# Altium schematic sheet PowerSupply.SchDoc
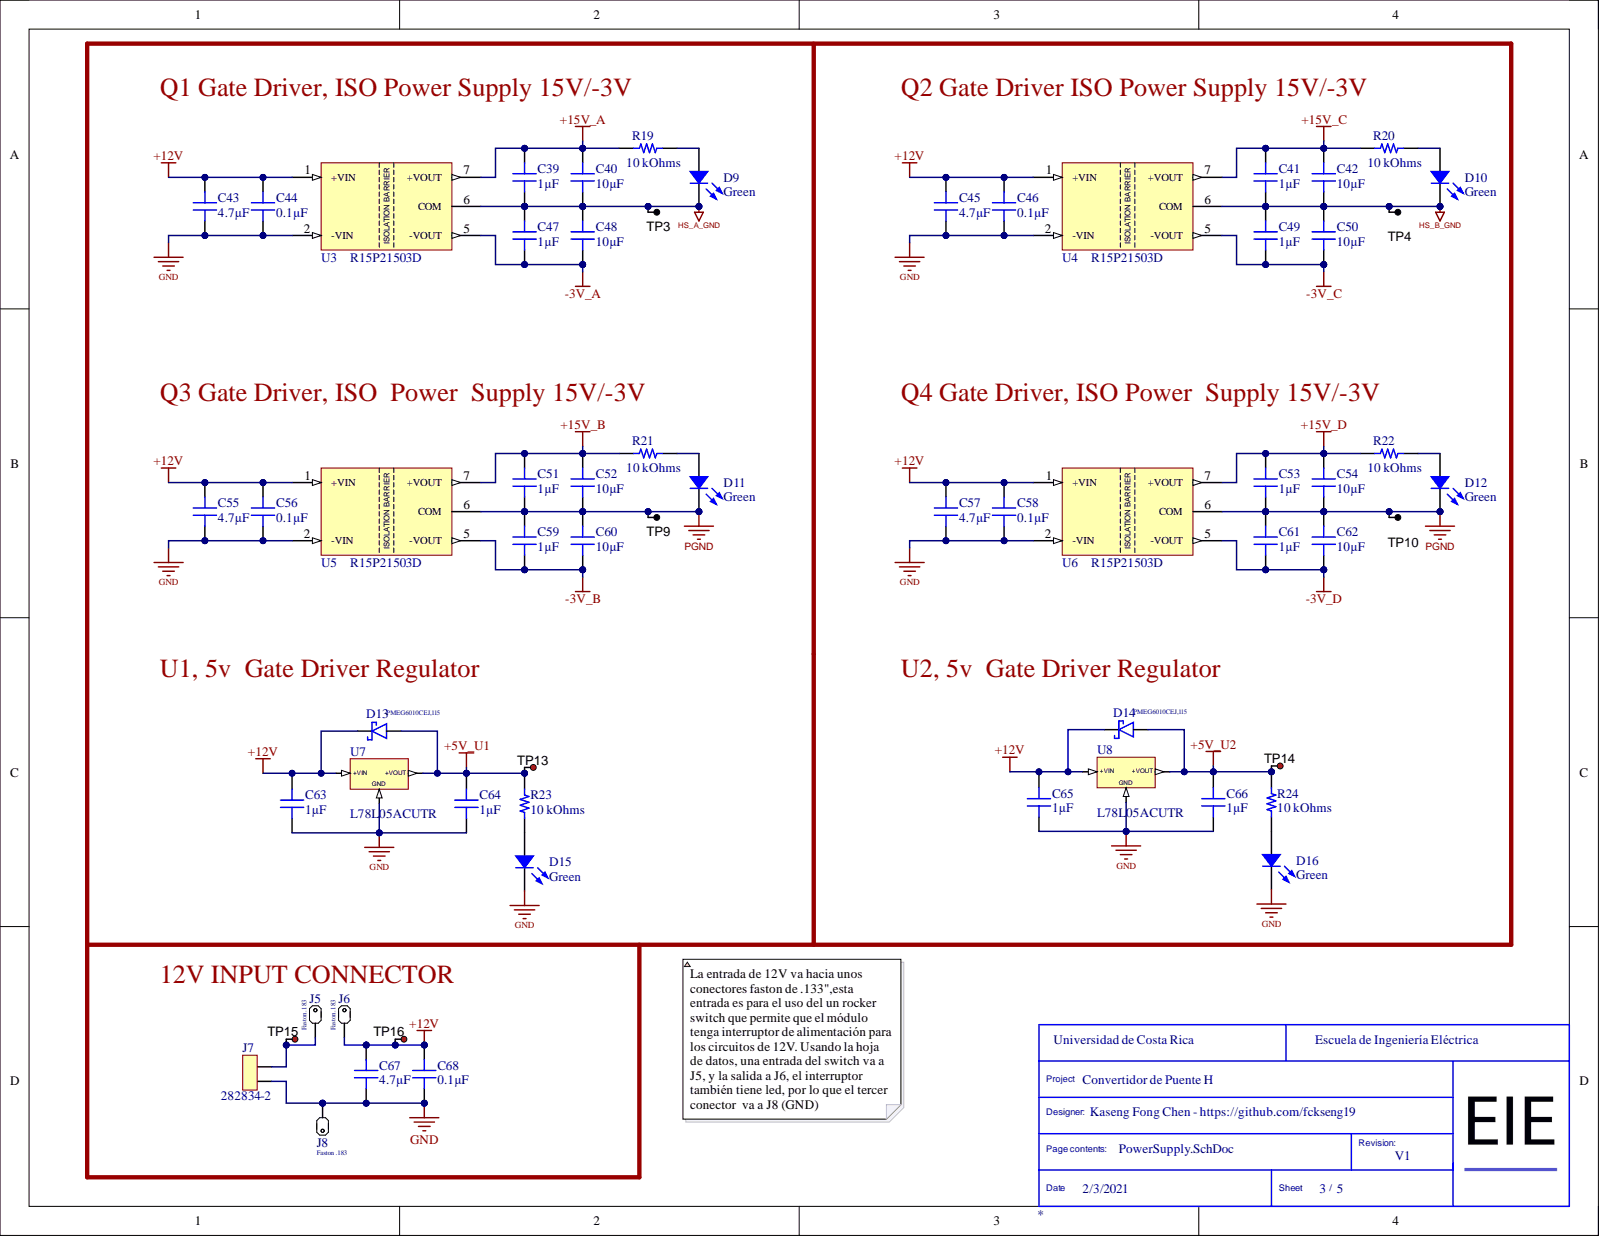
[diagram p0: PowerSupply.SchDoc]

## Parts
C39  1µF  [CAP 0603_1608]
C40  10µF  [CAP 1206_3216 - 1.8MM]
C41  1µF  [CAP 0603_1608]
C42  10µF  [CAP 1206_3216 - 1.8MM]
C43  4.7µF  [CAP 1206_3216 - 1.8MM]
C44  0.1µF  [CAP 0603_1608]
C45  4.7µF  [CAP 1206_3216 - 1.8MM]
C46  0.1µF  [CAP 0603_1608]
C47  1µF  [CAP 0603_1608]
C48  10µF  [CAP 1206_3216 - 1.8MM]
C49  1µF  [CAP 0603_1608]
C50  10µF  [CAP 1206_3216 - 1.8MM]
C51  1µF  [CAP 0603_1608]
C52  10µF  [CAP 1206_3216 - 1.8MM]
C53  1µF  [CAP 0603_1608]
C54  10µF  [CAP 1206_3216 - 1.8MM]
C55  4.7µF  [CAP 1206_3216 - 1.8MM]
C56  0.1µF  [CAP 0603_1608]
C57  4.7µF  [CAP 1206_3216 - 1.8MM]
C58  0.1µF  [CAP 0603_1608]
C59  1µF  [CAP 0603_1608]
C60  10µF  [CAP 1206_3216 - 1.8MM]
C61  1µF  [CAP 0603_1608]
C62  10µF  [CAP 1206_3216 - 1.8MM]
C63  1µF  [CAP 0603_1608]
C64  1µF  [CAP 0603_1608]
C65  1µF  [CAP 0603_1608]
C66  1µF  [CAP 0603_1608]
C67  4.7µF  [CAP 1206_3216 - 1.8MM]
C68  0.1µF  [CAP 0603_1608]
D9  Green  [LED 0603_1608 GREEN]
D10  Green  [LED 0603_1608 GREEN]
D11  Green  [LED 0603_1608 GREEN]
D12  Green  [LED 0603_1608 GREEN]
D13  PMEG6010CEJ,115  [SOD-323F]
D14  PMEG6010CEJ,115  [SOD-323F]
D15  Green  [LED 0603_1608 GREEN]
D16  Green  [LED 0603_1608 GREEN]
J5  Faston .183  [TE_1217133-1]
J6  Faston .183  [TE_1217133-1]
J7  282834-2  [TE 282834-2]
J8  Faston .183  [TE_1217133-1]
R19  10 kOhms  [RES 0603_1608]
R20  10 kOhms  [RES 0603_1608]
R21  10 kOhms  [RES 0603_1608]
R22  10 kOhms  [RES 0603_1608]
R23  10 kOhms  [RES 0603_1608]
R24  10 kOhms  [RES 0603_1608]
TP3  5011  [Keystone5011]  (value not drawn)
TP4  5011  [Keystone5011]  (value not drawn)
TP9  5011  [Keystone5011]  (value not drawn)
TP10  5011  [Keystone5011]  (value not drawn)
TP13  5010  [Keystone5010]  (value not drawn)
TP14  5010  [Keystone5010]  (value not drawn)
TP15  5010  [Keystone5010]  (value not drawn)
TP16  5010  [Keystone5010]  (value not drawn)
U3  R15P21503D  [CONV_R15P21503D]
U4  R15P21503D  [CONV_R15P21503D]
U5  R15P21503D  [CONV_R15P21503D]
U6  R15P21503D  [CONV_R15P21503D]
U7  L78L05ACUTR  [VREG_L78L12ABUTR]
U8  L78L05ACUTR  [VREG_L78L12ABUTR]

## Nets
+5V_U1: C64.2 D13.2 R23.1 TP13.1 U7.1
+5V_U2: C66.2 D14.2 R24.1 TP14.1 U8.1
+12V: C43.2 C44.2 C45.2 C46.2 C55.2 C56.2 C57.2 C58.2 C63.2 C65.2 C67.2 C68.2 D13.1 D14.1 J6.1 TP16.1 U3.1(+VIN) U4.1(+VIN) U5.1(+VIN) U6.1(+VIN) U7.3 U8.3
+15V_A: C39.2 C40.2 R19.1 U3.7(+VOUT)
+15V_B: C51.2 C52.2 R21.1 U5.7(+VOUT)
+15V_C: C41.2 C42.2 R20.1 U4.7(+VOUT)
+15V_D: C53.2 C54.2 R22.1 U6.7(+VOUT)
-3V_A: C47.1 C48.1 U3.5(-VOUT)
-3V_B: C59.1 C60.1 U5.5(-VOUT)
-3V_C: C49.1 C50.1 U4.5(-VOUT)
-3V_D: C61.1 C62.1 U6.5(-VOUT)
GND: C43.1 C44.1 C45.1 C46.1 C55.1 C56.1 C57.1 C58.1 C63.1 C64.1 C65.1 C66.1 C67.1 C68.1 D15.2 D16.2 J7.2 J8.1 U3.2(-VIN) U4.2(-VIN) U5.2(-VIN) U6.2(-VIN) U7.2 U8.2
HS_A_GND: C39.1 C40.1 C47.2 C48.2 D9.2 TP3.1 U3.6(COM)
HS_B_GND: C41.1 C42.1 C49.2 C50.2 D10.2 TP4.1 U4.6(COM)
PGND: C51.1 C52.1 C53.1 C54.1 C59.2 C60.2 C61.2 C62.2 D11.2 D12.2 TP9.1 TP10.1 U5.6(COM) U6.6(COM)
NetD9_1: D9.1 R19.2
NetD10_1: D10.1 R20.2
NetD11_1: D11.1 R21.2
NetD12_1: D12.1 R22.2
NetD15_1: D15.1 R23.2
NetD16_1: D16.1 R24.2
NetJ5_1: J5.1 J7.1 TP15.1

## Library data of the parts
C39 C41 C47 C49 C51 C53 C59 C61 = UMK107AB7105KA-T: CAP CER 1UF 50V X7R 0603; Manufacturer: Taiyo Yuden; Database Table Name: Capacitors - Ceramic - 0603; Tolerance: ±10%; Applications: General Purpose; Capacitance: 1µF; Component Kind: Standard; ComponentLink1Description: Datasheet; ComponentLink1URL: https://www.yuden.co.jp/productdata/catalog/mlcc01_e.pdf; ComponentLink2Description: DigiKey Link; ComponentLink2URL: http://digikey.com/product-detail/en/taiyo-yuden/UMK107AB7105KA-T/587-3247-1-ND/3660107; Device Package: 0603 (1608 Metric); LastUpdated: 2/9/2020 01:03:17; Library Name: Celestial Altium Library - altium_library.DbLib; Lifecycle Status: Active; Minimum Order: 1; Mounting Type: Surface Mount, MLCC; Operating Temperature: -55°C ~ 125°C; Package / Case: 0603 (1608 Metric); Packaging: TapeAndReel; Part Number: UMK107AB7105KA-T; Part Status: Active; PartId: 12796; Price: 0.05; Series: M; Size / Dimension: 0.063" L x 0.031" W (1.60mm x 0.80mm); Supplier 1: DigiKey; Supplier Part Number 1: 587-3247-1-ND; Temperature Coefficient: X7R; Thickness (Max): 0.037" (0.95mm); Value: 1µF; Voltage - Rated: 50V
C40 C42 C48 C50 C52 C54 C60 C62 = TMK316AB7106KL-T: CAP CER 10UF 25V X7R 1206; Manufacturer: Taiyo Yuden; Database Table Name: Capacitors - Ceramic - 1206; Tolerance: ±10%; Applications: General Purpose; Capacitance: 10µF; Component Kind: Standard; ComponentLink1Description: Datasheet; ComponentLink1URL: https://www.yuden.co.jp/productdata/catalog/mlcc01_e.pdf; ComponentLink2Description: DigiKey Link; ComponentLink2URL: http://digikey.com/product-detail/en/taiyo-yuden/TMK316AB7106KL-T/587-3486-1-ND/4157519; Device Package: 1206 (3216 Metric); LastUpdated: 2/9/2020 01:05:40; Library Name: Celestial Altium Library - altium_library.DbLib; Lifecycle Status: Active; Minimum Order: 1; Mounting Type: Surface Mount, MLCC; Operating Temperature: -55°C ~ 125°C; Package / Case: 1206 (3216 Metric); Packaging: TapeAndReel; Part Number: TMK316AB7106KL-T; Part Status: Active; PartId: 20052; Price: 0.08; Series: M; Size / Dimension: 0.126" L x 0.063" W (3.20mm x 1.60mm); Supplier 1: DigiKey; Supplier Part Number 1: 587-3486-1-ND; Temperature Coefficient: X7R; Thickness (Max): 0.071" (1.80mm); Value: 10µF; Voltage - Rated: 25V
C43 C45 C55 C57 C67 = UMK316AB7475KL-T: CAP CER 4.7UF 50V X7R 1206; Manufacturer: Taiyo Yuden; Database Table Name: Capacitors - Ceramic - 1206; Tolerance: ±10%; Applications: General Purpose; Capacitance: 4.7µF; Component Kind: Standard; ComponentLink1Description: Datasheet; ComponentLink1URL: https://www.yuden.co.jp/productdata/catalog/mlcc01_e.pdf; ComponentLink2Description: DigiKey Link; ComponentLink2URL: http://digikey.com/product-detail/en/taiyo-yuden/UMK316AB7475KL-T/587-2994-1-ND/2714187; Device Package: 1206 (3216 Metric); LastUpdated: 2/9/2020 01:05:40; Library Name: Celestial Altium Library - altium_library.DbLib; Lifecycle Status: Active; Minimum Order: 1; Mounting Type: Surface Mount, MLCC; Operating Temperature: -55°C ~ 125°C; Package / Case: 1206 (3216 Metric); Packaging: TapeAndReel; Part Number: UMK316AB7475KL-T; Part Status: Active; PartId: 20094; Price: 0.08; Series: M; Size / Dimension: 0.126" L x 0.063" W (3.20mm x 1.60mm); Supplier 1: DigiKey; Supplier Part Number 1: 587-2994-1-ND; Temperature Coefficient: X7R; Thickness (Max): 0.071" (1.80mm); Value: 4.7µF; Voltage - Rated: 50V
C44 C46 C56 C58 C68 = C0603C104M5RACTU: CAP CER 0.1UF 50V X7R 0603; Manufacturer: KEMET; Database Table Name: Capacitors - Ceramic - 0603; Tolerance: ±20%; Applications: Bypass, Decoupling; Capacitance: 0.1µF; Component Kind: Standard; ComponentLink1Description: Datasheet; ComponentLink1URL: https://api.kemet.com/component-edge/download/datasheet/C0603C104M5RACTU.pdf; ComponentLink2Description: DigiKey Link; ComponentLink2URL: http://digikey.com/product-detail/en/kemet/C0603C104M5RACTU/399-7845-1-ND/3471568; Device Package: 0603 (1608 Metric); LastUpdated: 2/9/2020 01:03:01; Library Name: Celestial Altium Library - altium_library.DbLib; Lifecycle Status: Active; Minimum Order: 1; Mounting Type: Surface Mount, MLCC; Operating Temperature: -55°C ~ 125°C; Package / Case: 0603 (1608 Metric); Packaging: TapeAndReel; Part Number: C0603C104M5RACTU; Part Status: Active; PartId: 17854; Price: 0.01; Series: SMD Comm X7R; Size / Dimension: 0.063" L x 0.031" W (1.60mm x 0.80mm); Supplier 1: DigiKey; Supplier Part Number 1: 399-7845-1-ND; Temperature Coefficient: X7R; Thickness (Max): 0.034" (0.87mm); Value: 0.1µF; Voltage - Rated: 50V
C63 C65 = TMK107B7105KA-T: CAP CER 1UF 25V X7R 0603; Manufacturer: Taiyo Yuden; Database Table Name: Capacitors - Ceramic - 0603; Tolerance: ±10%; Applications: General Purpose; Capacitance: 1µF; Component Kind: Standard; ComponentLink1Description: Datasheet; ComponentLink1URL: https://www.yuden.co.jp/productdata/catalog/mlcc01_e.pdf; ComponentLink2Description: DigiKey Link; ComponentLink2URL: http://digikey.com/product-detail/en/taiyo-yuden/TMK107B7105KA-T/587-2984-1-ND/2714177; Device Package: 0603 (1608 Metric); LastUpdated: 2/9/2020 01:03:30; Library Name: Celestial Altium Library - altium_library.DbLib; Lifecycle Status: Active; Minimum Order: 1; Mounting Type: Surface Mount, MLCC; Operating Temperature: -55°C ~ 125°C; Package / Case: 0603 (1608 Metric); Packaging: TapeAndReel; Part Number: TMK107B7105KA-T; Part Status: Active; PartId: 5586; Price: 0.01; Series: M; Size / Dimension: 0.063" L x 0.031" W (1.60mm x 0.80mm); Supplier 1: DigiKey; Supplier Part Number 1: 587-2984-1-ND; Temperature Coefficient: X7R; Thickness (Max): 0.035" (0.90mm); Value: 1µF; Voltage - Rated: 25V
C64 C66 = CC0603KRX7R7BB105: CAP CER 1UF 16V X7R 0603; Manufacturer: Yageo; Database Table Name: Capacitors - Ceramic - 0603; Tolerance: ±10%; Applications: General Purpose; Capacitance: 1µF; Component Kind: Standard; ComponentLink1Description: Datasheet; ComponentLink1URL: https://www.yageo.com/upload/media/product/productsearch/datasheet/mlcc/UPY-GPHC_X7R_6.3V-to-50V_18.pdf; ComponentLink2Description: DigiKey Link; ComponentLink2URL: http://digikey.com/product-detail/en/yageo/CC0603KRX7R7BB105/311-1446-1-ND/2833752; Device Package: 0603 (1608 Metric); LastUpdated: 2/9/2020 01:03:31; Library Name: Celestial Altium Library - altium_library.DbLib; Lifecycle Status: Active; Minimum Order: 1; Mounting Type: Surface Mount, MLCC; Operating Temperature: -55°C ~ 125°C; Package / Case: 0603 (1608 Metric); Packaging: TapeAndReel; Part Number: CC0603KRX7R7BB105; Part Status: Active; PartId: 5233; Price: 0.01; Series: CC; Size / Dimension: 0.063" L x 0.031" W (1.60mm x 0.80mm); Supplier 1: DigiKey; Supplier Part Number 1: 311-1446-1-ND; Temperature Coefficient: X7R; Thickness (Max): 0.035" (0.90mm); Value: 1µF; Voltage - Rated: 16V
D9-D12 D15 D16 = 150060GS75000: LED GREEN CLEAR 0603 SMD; Manufacturer: Würth Elektronik; Configuration: Standard; Current - Test: 20mA; Database Table Name: LED - Indication; Lens Color: Colorless; Lens Size: 1.10mm x 0.70mm; Lens Style: Rectangle with Flat Top; Millicandela Rating: 430mcd; Viewing Angle: 140°; Voltage - Forward (Vf) (Typ): 3.2V; Wavelength - Dominant: 520nm; Wavelength - Peak: 515nm; Color: Green; Component Kind: Standard; ComponentLink1Description: Datasheet; ComponentLink1URL: https://katalog.we-online.de/led/datasheet/150060GS75000.pdf; ComponentLink2Description: DigiKey Link; ComponentLink2URL: http://digikey.com/product-detail/en/w-rth-elektronik/150060GS75000/732-4971-1-ND/4489896; Device Package: 0603 (1608 Metric); Height (Max): 0.80mm; LastUpdated: 2/9/2020 01:20:41; Lens Transparency: Clear; Library Name: Celestial Altium Library - altium_library.DbLib; Lifecycle Status: Active; Minimum Order: 1; Mounting Type: Surface Mount; Package / Case: 0603 (1608 Metric); Packaging: TapeAndReel; Part Number: 150060GS75000; Part Status: Active; PartId: 686; Price: 0.10; Series: WL-SMCW; Size / Dimension: 1.60mm L x 0.80mm W; Supplier 1: DigiKey; Supplier Device Package: 0603; Supplier Part Number 1: 732-4971-1-ND; Value: Green
D13 D14 = PMEG6010CEJ,115: PMEG6010CEH; PMEG6010CEJ - 1 A very low VF MEGA Schottky barrier rectifiers; Manufacturer: Nexperia; Category: Rectifier Diodes; Component Kind: Standard; ComponentLink1Description: https://www.mouser.com/ds/2/302/PMEG6010CEH_PMEG6010CEJ-102368.pdf?src-supplier=Mouser; ComponentLink1URL: https://www.mouser.com/ds/2/302/PMEG6010CEH_PMEG6010CEJ-102368.pdf?src-supplier=Mouser; ComponentLink2Description: http://www.farnell.com/datasheets/109684.pdf?src-supplier=Farnell; ComponentLink2URL: http://www.farnell.com/datasheets/109684.pdf?src-supplier=Farnell; ComponentLink3Description: http://www.farnell.com/datasheets/109684.pdf?src-supplier=Newark; ComponentLink3URL: http://www.farnell.com/datasheets/109684.pdf?src-supplier=Newark; ComponentLink4Description: https://assets.nexperia.com/documents/data-sheet/PMEG6010CEH_PMEG6010CEJ.pdf?src-supplier=Digi-Key; ComponentLink4URL: https://assets.nexperia.com/documents/data-sheet/PMEG6010CEH_PMEG6010CEJ.pdf?src-supplier=Digi-Key; Manufacturer Part Number: PMEG6010CEJ,115; Pricing 1: 1=0,35000, 10=0,27200, 100=0,16340, 500=0,15128, 1000=0,10288 (USD); RoHS: Yes; Stock 1: 105369; Supplier 1: Digi-Key; Supplier Part Number 1: 1727-3882-1-ND; Library Name: KasengLIb.SchLib
J5 J6 J8 = 1217133-1: FASTON 187 PCB TAB TPBR; MF: TE Connectivity; Availability: Good; Check_prices: https://www.snapeda.com/parts/1217133-1/TE%20Connectivity%20AMP%20Connectors/view-part/660570/?ref=eda; Component Kind: Standard; EU_RoHS_Compliance: Compliant; Library Name: 1217133-1.IntLib; MP: 1217133-1; Package: None; Price: None; Purchase-URL: https://pricing.snapeda.com/search/part/1217133-1/?ref=eda; SnapEDA_Link: https://www.snapeda.com/parts/1217133-1/TE%20Connectivity%20AMP%20Connectors/view-part/660570/?ref=snap
J7 = 282834-2: TE CONNECTIVITY / BUCHANAN - 282834-2 - TERMINAL BLOCK, WIRE TO BRD, 2POS, 16AWG; Approvals: UL; Closed End: No (Without); Connector Type: Receptacle; Current: 10A; Gender: Male; Max Supply Voltage (AC): 150V; Number of Circuits: 2; Number of Levels: 1; Pitch: 2.54mm; Plating: Brass,Steel; Screwless Terminal Block: Yes (With); Tail Length: 3.4mm; Thread Size: M1.6; Wire Gauge (Max): 30AWG; Category: Terminal Blocks; Color: Green; Component Kind: Standard; ComponentLink1Description: https://datasheet.ciiva.com/11758/0900766b80e7dc96-11758675.pdf?src-supplier=RSComponents; ComponentLink1URL: https://datasheet.ciiva.com/11758/0900766b80e7dc96-11758675.pdf?src-supplier=RSComponents; ComponentLink2Description: https://datasheet.ciiva.com/16386/282834-2-16386363.pdf?src-supplier=Future+Electronics; ComponentLink2URL: https://datasheet.ciiva.com/16386/282834-2-16386363.pdf?src-supplier=Future+Electronics; ComponentLink3Description: https://datasheet.ciiva.com/14609/70086732-14609670.pdf?src-supplier=Allied+Electronics; ComponentLink3URL: https://datasheet.ciiva.com/14609/70086732-14609670.pdf?src-supplier=Allied+Electronics; ComponentLink4Description: https://datasheet.ciiva.com/15169/getdatasheetpartid-155864-15169558.pdf?src-supplier=Verical; ComponentLink4URL: https://datasheet.ciiva.com/15169/getdatasheetpartid-155864-15169558.pdf?src-supplier=Verical; ComponentLink5Description: https://datasheet.ciiva.com/16676/eng-cs-1308389-eurostyle-terminal-blocks-0607-16676126.pdf?src-supplier=Avnet+Europe; ComponentLink5URL: https://datasheet.ciiva.com/16676/eng-cs-1308389-eurostyle-terminal-blocks-0607-16676126.pdf?src-supplier=Avnet+Europe; ComponentLink6Description: https://datasheet.ciiva.com/30166/eng-cs-1308389-eurostyle-terminal-blocks-0607-30166974.pdf?src-supplier=Onlinecomponents.com; ComponentLink6URL: https://datasheet.ciiva.com/30166/eng-cs-1308389-eurostyle-terminal-blocks-0607-30166974.pdf?src-supplier=Onlinecomponents.com; ComponentLink7Description: https://datasheet.ciiva.com/3141/eng-cs-1308389-eurostyle-terminal-blocks-0607-3141115.pdf?src-supplier=Avnet; ComponentLink7URL: https://datasheet.ciiva.com/3141/eng-cs-1308389-eurostyle-terminal-blocks-0607-3141115.pdf?src-supplier=Avnet; ComponentLink8Description: https://datasheet.ciiva.com/823/eng-cd-282834-c1-823453.pdf?src-supplier=Digi-Key; ComponentLink8URL: https://datasheet.ciiva.com/823/eng-cd-282834-c1-823453.pdf?src-supplier=Digi-Key; Contact Material: Brass; Contact Plating: Tin; Current Rating: 10A; Depth: 6.5mm; ELV: Compliant; Flammability Rating: UL94 V-0; Housing Color: Green; Housing Material: Polyamide; Interlock: No (Without); Lead Free: Lead Free; Length: 5.54mm; Manufacturer: TE Connectivity; Manufacturer Part Number: 282834-2; Material: Nylon; Max Current Rating: 10A; Max Operating Temperature: 105°C; Max Voltage Rating (AC): 150V; Min Operating Temperature: -40°C; Mount: Through Hole; Number of Contacts: 2; Number of Poles: 2; Number of Positions: 2; Number of Positions Per Level: 2; Number of Rows: 1; Orientation: Straight; Package Quantity: 300; Packaging: Bulk; Pricing 1: 1=1,27000, 10=0,89500, 25=0,83440, 50=0,75740, 100=0,75410, 250=0,63676, 500=0,60324, 1000=0,53622, 5000=0,50270 (USD); Radiation Hardening: No; REACH SVHC: Unknown; RoHS: Yes; RoHS Compliant: Yes; Screw Flange: No (Without); Series: Buchanan; Side Stackable: Yes (With); Stock 1: 21575; Supplier 1: Digi-Key; Supplier Part Number 1: A98333-ND; Termination: Solder; Voltage: 150V; Voltage Rating: 150V; Width: 6.5mm; Wire Gauge (Min): 30AWG; Wire/Cable Gauge: 30AWG; Library Name: kasengLib.IntLib
R19-R24 = RC0603FR-0710KL: RES SMD 10K OHM 1% 1/10W 0603; Manufacturer: Yageo; Database Table Name: Resistors - Surface Mount - 0603; Power (Watts): 0.1W, 1/10W; Temperature Coefficient: ±100ppm/°C; Component Kind: Standard; ComponentLink1Description: Datasheet; ComponentLink1URL: https://www.yageo.com/upload/media/product/productsearch/datasheet/rchip/PYu-RC_Group_51_RoHS_L_10.pdf; ComponentLink2Description: DigiKey Link; ComponentLink2URL: http://digikey.com/product-detail/en/yageo/RC0603FR-0710KL/311-10.0KHRCT-ND/729827; Composition: Thick Film; Device Package: 0603 (1608 Metric); Features: Moisture Resistant; Height - Seated (Max): 0.022" (0.55mm); LastUpdated: 2/9/2020 01:09:45; Library Name: Celestial Altium Library - altium_library.DbLib; Lifecycle Status: Active; Minimum Order: 1; Number of Terminations: 2; Operating Temperature: -55°C ~ 155°C; Package / Case: 0603 (1608 Metric); Packaging: CutTape; Part Number: RC0603FR-0710KL; Part Status: Active; PartId: 22599; Price: 0.10; Resistance: 10 kOhms; Series: RC; Size / Dimension: 0.063" L x 0.031" W (1.60mm x 0.80mm); Supplier 1: DigiKey; Supplier Device Package: 0603; Supplier Part Number 1: 311-10.0KHRCT-ND; Tolerance: ±1%; Value: 10 kOhms
TP3 TP4 TP9 TP10 = 5011: Test Point, Multipurpose, Black, TH; Manufacturer: Keystone; CompType: Test Point Loop; ContactFinish: Silver; Color: Black; Component Kind: Standard; ComponentLink1Description: Datasheet URL; ComponentLink1URL: http://www.keyelco.com/userAssets/file/M60-2p60.pdf; LatestRevisionDate: 2016-02-11; MountType: TH; PackageReference: Black Multipurpose Testpoint; PartNumber: 5011; RoHS: Y; Supplier 1: Digi-Key; Supplier 2: Mouser; Supplier Part Number 1: 36-5011-ND; Supplier Part Number 2: 534-5011; SymbolVersion: 2008-04-04; Library Name: kasengLib.IntLib; Publisher: Texas Instruments
TP13-TP16 = 5010: Test Point, Multipurpose, Red, TH; Manufacturer: Keystone; CompType: Test Point Loop; ContactFinish: Silver; Color: Red; Component Kind: Standard; ComponentLink1Description: Datasheet URL; ComponentLink1URL: http://www.keyelco.com/userAssets/file/M60-2p60.pdf; LatestRevisionDate: 2016-02-11; MountType: TH; PackageReference: Red Multipurpose Testpoint; PartNumber: 5010; RoHS: Y; Supplier 1: Digi-Key; Supplier 2: Mouser; Supplier Part Number 1: 36-5010-ND; Supplier Part Number 2: 534-5010; SymbolVersion: 2008-04-04; Library Name: kasengLib.IntLib; Publisher: Texas Instruments
U3-U6 = R15P21503D: 2W DC/DC-Converter 'ECONOLINE' SIP7 6.4kV unreg; V. In: 15; V. Out: +15/-03; mA. Out: +93/-185; Manufacturer: Recom; Max Output Voltage: 15V; Category: Voltage Regulators - Switching; Component Kind: Standard; ComponentLink1Description: https://www.recom-power.com/pdf/Econoline/RxxP2xxyy.pdf?src-supplier=Digi-Key; ComponentLink1URL: https://www.recom-power.com/pdf/Econoline/RxxP2xxyy.pdf?src-supplier=Digi-Key; Height: 12.5mm; Length: 19.5mm; Manufacturer Part Number: R15P21503D; Pricing 1: 1=8,47000, 5=8,31800, 10=8,25500, 25=8,12800, 50=7,87400, 100=7,26000, 250=6,98500, 500=6,85800 (USD); RoHS: Yes; RoHS Compliant: Yes; Stock 1: 1614; Supplier 1: Digi-Key; Supplier Part Number 1: 945-3070-5-ND; Weight: 4.3g; Width: 9.8mm; Library Name: KasengLIb.SchLib
U7 U8 = L78L05ACUTR: Voltage regulator; linear, fixed; 5V; 0.1A; SOT89; SMD; 0÷125°C; Manufacturer: STMicroelectronics; Accuracy: 4%; Dropout Voltage: 1.7V; Max Input Voltage: 30V; Max Output Current: 100mA; Min Input Voltage: 7V; Number of Outputs: 1; Polarity: Positive; Power Supply Rejection Ratio (PSRR): 49dB; Quiescent Current: 6mA; Case/Package: SOT-89; Category: Voltage Regulators - Linear; Component Kind: Standard; ComponentLink1Description: https://datasheet.ciiva.com/2041/cd00000446-2041792.pdf?src-supplier=Digi-Key; ComponentLink1URL: https://datasheet.ciiva.com/2041/cd00000446-2041792.pdf?src-supplier=Digi-Key; ComponentLink2Description: https://datasheet.ciiva.com/1511/1868798-1511940.pdf?src-supplier=Element14; ComponentLink2URL: https://datasheet.ciiva.com/1511/1868798-1511940.pdf?src-supplier=Element14; ComponentLink3Description: https://datasheet.ciiva.com/7992/1868798-7992600.pdf?src-supplier=Element14; ComponentLink3URL: https://datasheet.ciiva.com/7992/1868798-7992600.pdf?src-supplier=Element14; ComponentLink4Description: https://datasheet.ciiva.com/16393/cd00000446-16393708.pdf?src-supplier=Future+Electronics; ComponentLink4URL: https://datasheet.ciiva.com/16393/cd00000446-16393708.pdf?src-supplier=Future+Electronics; ComponentLink5Description: https://datasheet.ciiva.com/22710/1868798-22710940.pdf?src-supplier=Element14; ComponentLink5URL: https://datasheet.ciiva.com/22710/1868798-22710940.pdf?src-supplier=Element14; ComponentLink6Description: https://datasheet.ciiva.com/4882/cd00000446-355303-4882429.pdf?src-supplier=Mouser; ComponentLink6URL: https://datasheet.ciiva.com/4882/cd00000446-355303-4882429.pdf?src-supplier=Mouser; ComponentLink7Description: https://datasheet.ciiva.com/2041/cd00000446-2041790.pdf?src-supplier=Digi-Key; ComponentLink7URL: https://datasheet.ciiva.com/2041/cd00000446-2041790.pdf?src-supplier=Digi-Key; ComponentLink8Description: https://datasheet.ciiva.com/2041/cd00000446-2041791.pdf?src-supplier=Digi-Key; ComponentLink8URL: https://datasheet.ciiva.com/2041/cd00000446-2041791.pdf?src-supplier=Digi-Key; Contact Plating: Tin,Matte; Height: 1.6mm; Lead Free: Lead Free; Length: 4.6mm; Manufacturer Part Number: L78L05ACUTR; Max Operating Temperature: 125°C; Min Operating Temperature: 0°C; Min Output Voltage: 5V; Mount: Surface Mount; Nominal Output Voltage: 5V; Number of Pins: 3; Number of Regulators: 1; Output Current: 100mA; Output Type: Fixed; Output Voltage: 5V; Output Voltage Accuracy: 4%; Package Quantity: 1; Packaging: Tape and Reel; Pricing 1: 1=0,53000, 10=0,43300, 25=0,39600, 100=0,26710, 250=0,22108, 500=0,20266, 1000=0,17380 (USD); Radiation Hardening: No; REACH SVHC: No SVHC; RoHS: Yes; RoHS Compliant: Yes; Series: -; Stock 1: 40018; Supplier 1: Digi-Key; Supplier Part Number 1: 497-1183-1-ND; Weight: 0.004603oz; Width: 2.6mm; Library Name: KasengLIb.SchLib
